# Altium schematic sheet AMS_Slave_Measurement.SchDoc
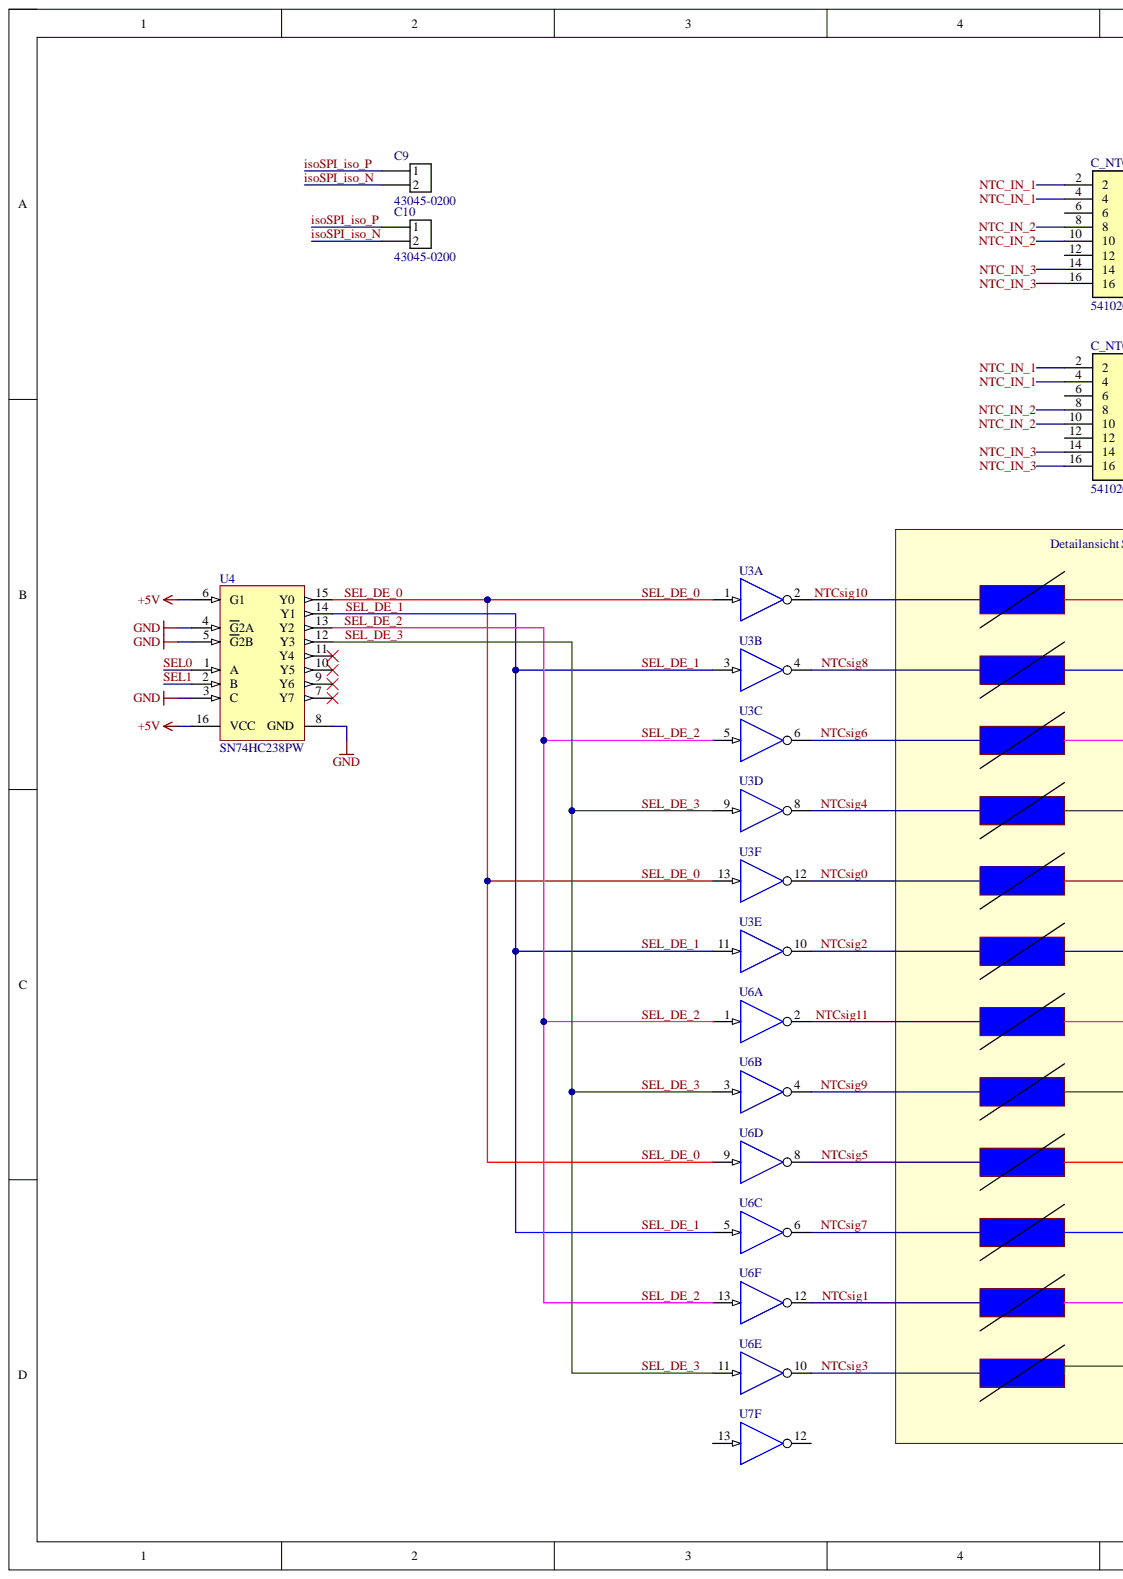
[diagram p0: AMS_Slave_Measurement.SchDoc, left]
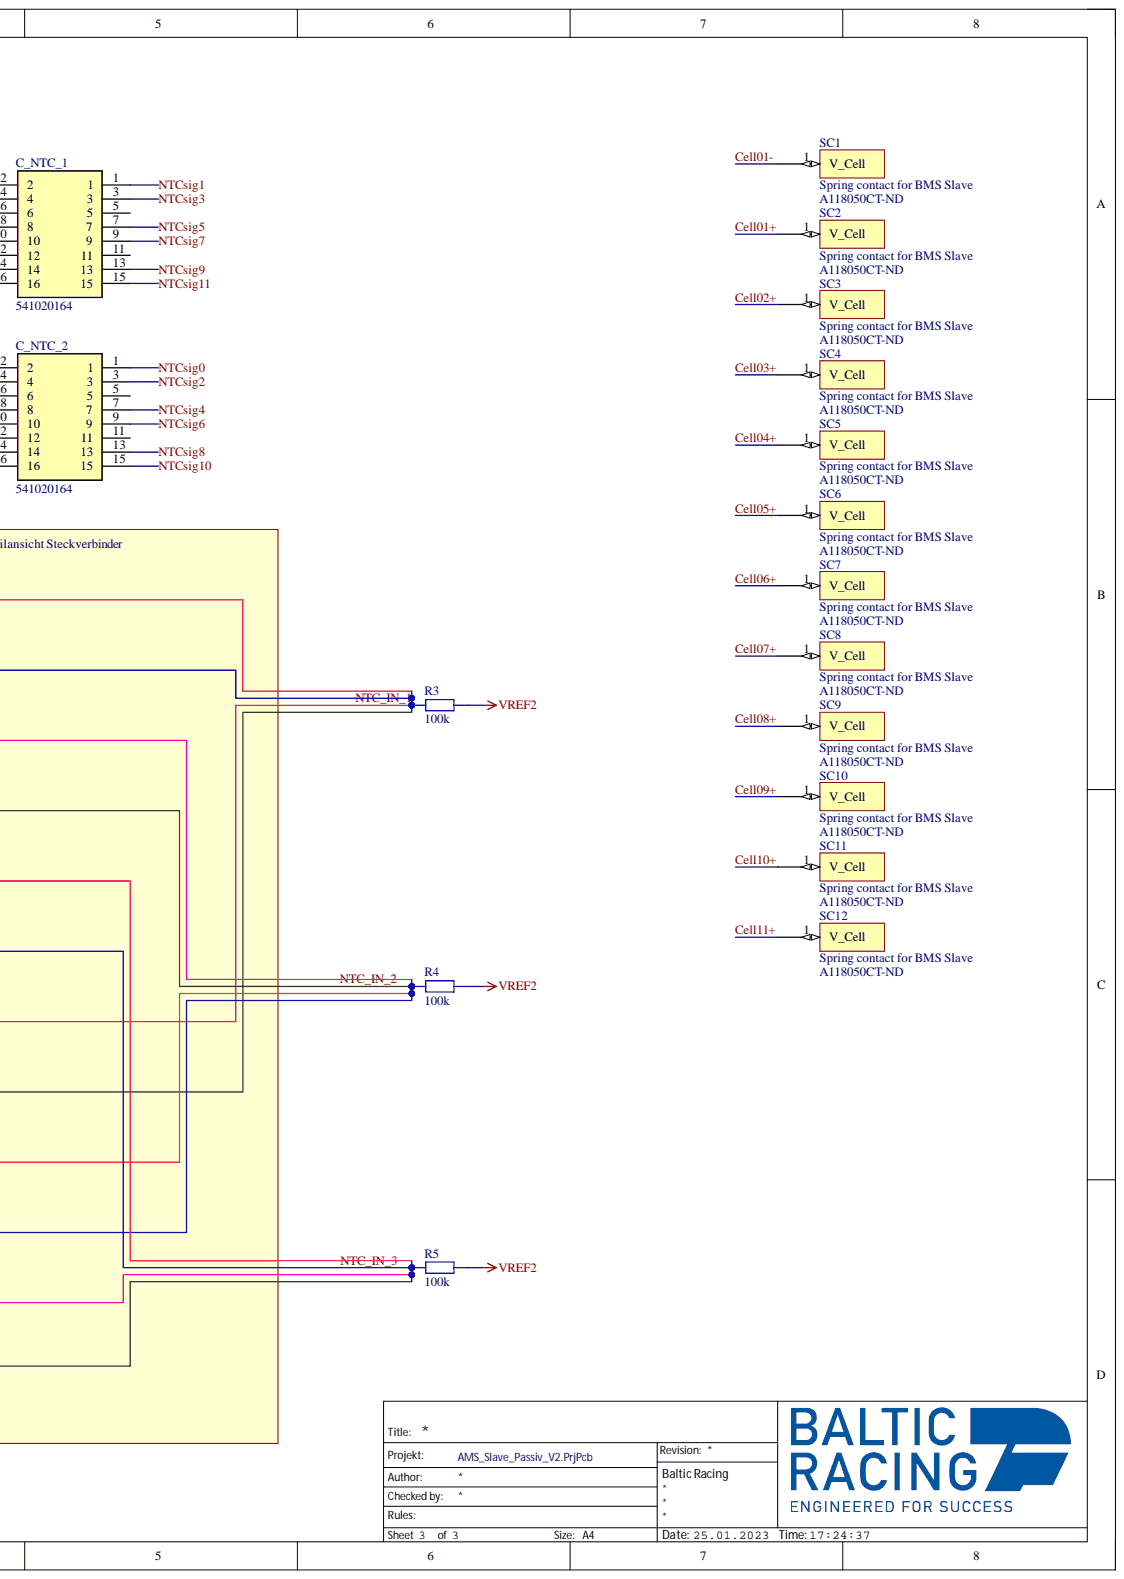
[diagram p1: AMS_Slave_Measurement.SchDoc, right]

## Parts
C9  43045-0200  [43650-0200]
C10  43045-0200  [43650-0200]
C_NTC_1  541020164  [541020164]
C_NTC_2  541020164  [541020164]
R3  value 100k  [STACKPOLE CSR0603]  (hidden comment: SMD Resistor)
R4  value 100k  [STACKPOLE CSR0603]  (hidden comment: SMD Resistor)
R5  value 100k  [STACKPOLE CSR0603]  (hidden comment: SMD Resistor)
SC1  Spring contact for BMS Slave  [1734300-1 Spring Contact]
SC2  Spring contact for BMS Slave  Supplier Part Number 1: A118050CT-ND  [1734300-1 Spring Contact]
SC3  Spring contact for BMS Slave  Supplier Part Number 1: A118050CT-ND  [1734300-1 Spring Contact]
SC4  Spring contact for BMS Slave  Supplier Part Number 1: A118050CT-ND  [1734300-1 Spring Contact]
SC5  Spring contact for BMS Slave  Supplier Part Number 1: A118050CT-ND  [1734300-1 Spring Contact]
SC6  Spring contact for BMS Slave  Supplier Part Number 1: A118050CT-ND  [1734300-1 Spring Contact]
SC7  Spring contact for BMS Slave  Supplier Part Number 1: A118050CT-ND  [1734300-1 Spring Contact]
SC8  Spring contact for BMS Slave  Supplier Part Number 1: A118050CT-ND  [1734300-1 Spring Contact]
SC9  Spring contact for BMS Slave  Supplier Part Number 1: A118050CT-ND  [1734300-1 Spring Contact]
SC10  Spring contact for BMS Slave  Supplier Part Number 1: A118050CT-ND  [1734300-1 Spring Contact]
SC11  Spring contact for BMS Slave  Supplier Part Number 1: A118050CT-ND  [1734300-1 Spring Contact]
SC12  Spring contact for BMS Slave  Supplier Part Number 1: A118050CT-ND  [1734300-1 Spring Contact]
U3  SN74LVC06APWR  [PW0014A_M]  (value not drawn)  (parts A,B,C,D,E,F)
U4  SN74HC238PW  [SN74HC238PW Footprint]
U6  SN74LVC06APWR  [PW0014A_M]  (value not drawn)  (parts A,B,C,D,E,F)
U7  SN74LVC06APWR  [PW0014A_M]  (value not drawn)  (part F)

## Nets
+5V: U4.6(G1) U4.16(VCC)
GND: U4.3(C) U4.4(G2A) U4.5(G2B) U4.8(GND)
VREF2: R3.2 R4.2 R5.2
Cell01+: SC2.1
Cell01-: SC1.1
Cell02+: SC3.1
Cell03+: SC4.1
Cell04+: SC5.1
Cell05+: SC6.1
Cell06+: SC7.1
Cell07+: SC8.1
Cell08+: SC9.1
Cell09+: SC10.1
Cell10+: SC11.1
Cell11+: SC12.1
isoSPI_iso_N: C9.2 C10.2
isoSPI_iso_P: C9.1 C10.1
NTC_IN_1: C_NTC_1.2 C_NTC_1.4 C_NTC_2.2 C_NTC_2.4 R3.1
NTC_IN_2: C_NTC_1.8 C_NTC_1.10 C_NTC_2.8 C_NTC_2.10 R4.1
NTC_IN_3: C_NTC_1.14 C_NTC_1.16 C_NTC_2.14 C_NTC_2.16 R5.1
NTCsig0: C_NTC_2.1 U3.12
NTCsig1: C_NTC_1.1 U6.12
NTCsig2: C_NTC_2.3 U3.10
NTCsig3: C_NTC_1.3 U6.10
NTCsig4: C_NTC_2.7 U3.8
NTCsig5: C_NTC_1.7 U6.8
NTCsig6: C_NTC_2.9 U3.6
NTCsig7: C_NTC_1.9 U6.6
NTCsig8: C_NTC_2.13 U3.4
NTCsig9: C_NTC_1.13 U6.4
NTCsig10: C_NTC_2.15 U3.2
NTCsig11: C_NTC_1.15 U6.2
SEL0: U4.1(A)
SEL1: U4.2(B)
SEL_DE_0: U3.1 U3.13 U4.15(Y0) U6.9
SEL_DE_1: U3.3 U3.11 U4.14(Y1) U6.5
SEL_DE_2: U3.5 U4.13(Y2) U6.1 U6.13
SEL_DE_3: U3.9 U4.12(Y3) U6.3 U6.11

Not connected: C_NTC_1 5 6 11 12; C_NTC_2 5 6 11 12; U4 7(Y7) 9(Y6) 10(Y5) 11(Y4); U7 12 13

## Library data of the parts
C9 C10 = MicroFit3.0 2-Pin: Molex Micro-Fit 3.0 2-Pin  600V  8.5A; Component Kind: Standard; Library Name: Standardbauteile.IntLib
C_NTC_1 C_NTC_2 = 541020164: 0.50mm Pitch SlimStack Receptacle, Surface Mount, Dual Row, Vertical, 2.50mm Stacking Height, Gold Plating with Nickel Barrier, 16 Circuits; Component Kind: Standard; Library Name: libNico.IntLib
R3-R5 = SMD Resistor: Resistor with multiple footprints; Component Kind: Standard; Library Name: Standardbauteile.IntLib
SC1-SC12 = 1734300-1: PCB Spring Contact, C-Clip, Uncompressed Height 3 mm [.118 in], Length 4.5 mm [.177 in], Width .098 in [2.5 mm]; Component Kind: Standard; Supplier 1: Digi-Key; Library Name: Integrated_LibraryLukas.IntLib
  SC1: Supplier Part Number 1: A118050CT-ND
U3 U6 U7 = CMP-1615-01057-2: Hex Inverter Buffers/Drivers With Open-Drain Outputs, PW0014A, LARGE T&R; Manufacturer: Texas Instruments; Bits(#): 6; F @ Nom Voltage(Max)(Mhz): 100; ICC @ Nom Voltage(Max)(mA): 0.04; Input Type: CMOS,TTL; Output Drive (IOL/IOH)(Max)(mA): -24/24; Output Type: CMOS; Rating: Catalog; Schmitt Trigger: No; Technology Family: LVC; tpd @ Nom Voltage(Max)(ns): 5.6,3.1,3.9,3.7; VCC(Max)(V): 3.6; VCC(Min)(V): 1.65; Voltage(Nom)(V): 1.8,2.5,2.7,3.3; Code_JEDEC: MO-153; Component Kind: Standard; DatasheetVersion: SCAS596N; Generic Part Number: SN74LVC06A; Library Name: Altium Content Vault; Operating Temperature Range(C): -40 to 125; PackageReference: PW0014A
U4 = SN74HC238PW: Component Kind: Standard; Library Name: Integrated_LibraryLukas_New.IntLib
